# Altium schematic sheet BP6A_EVK_3.SchDoc(BP6A_EVK_3)
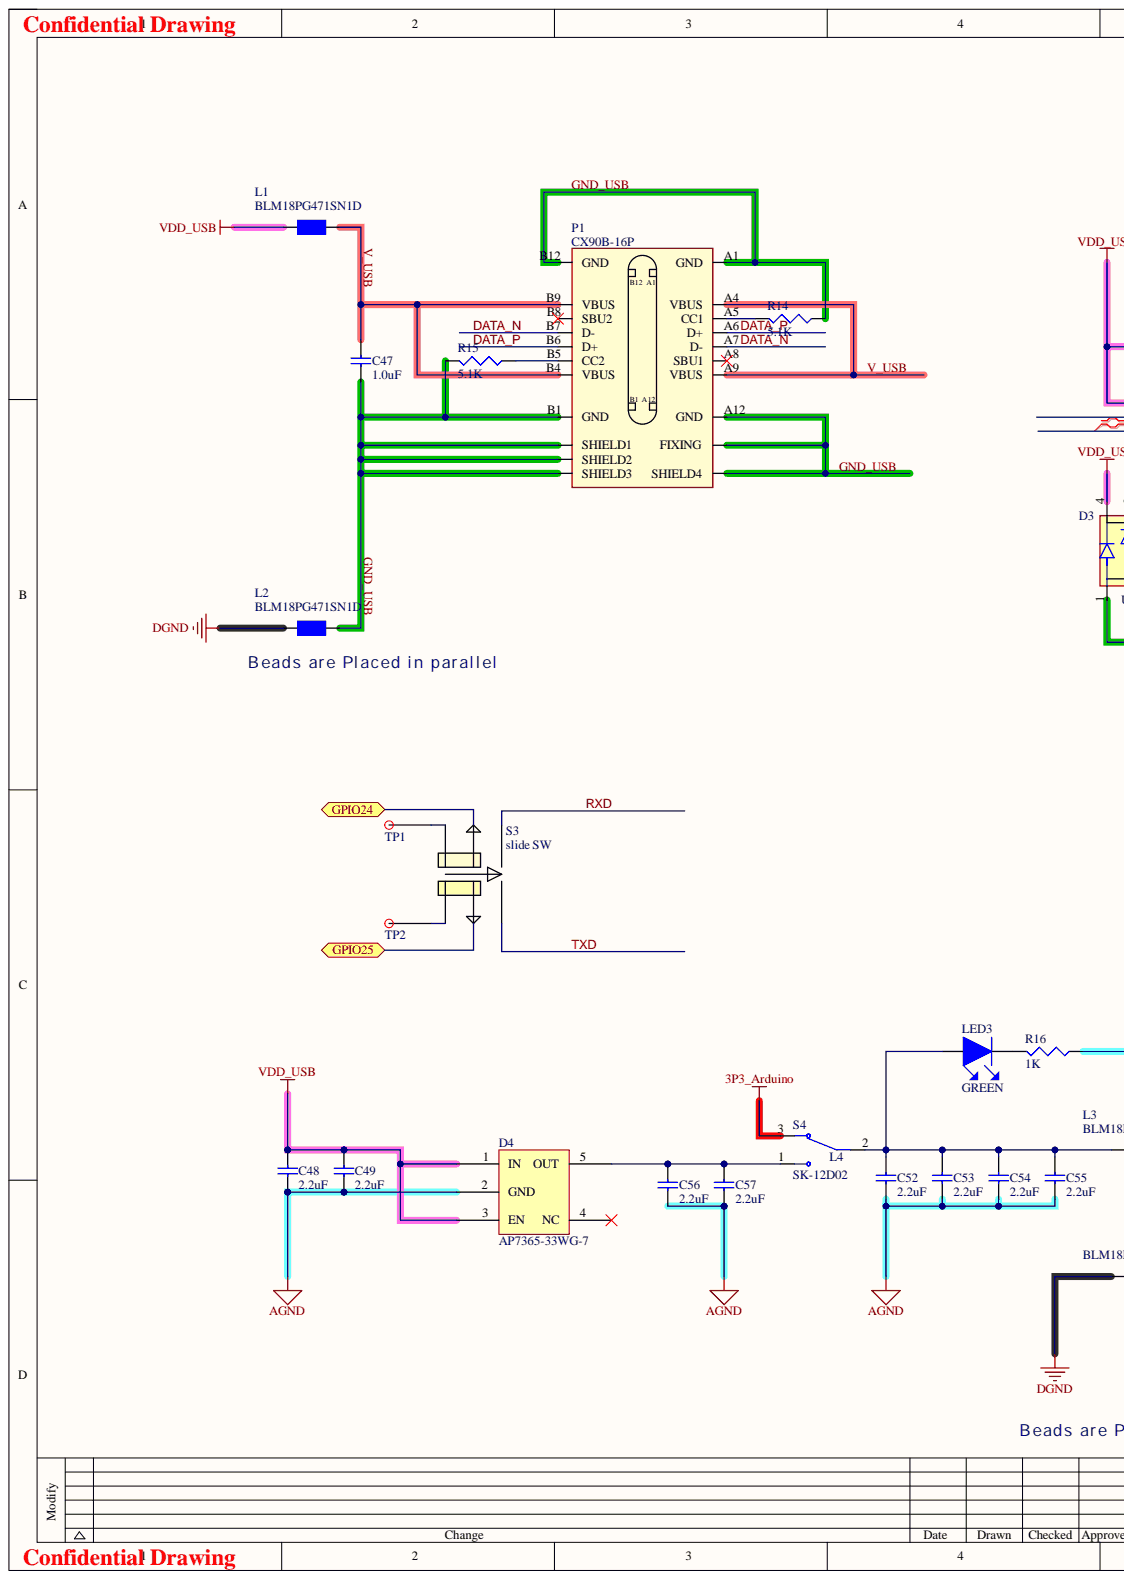
[diagram p0: BP6A_EVK_3.SchDoc, left]
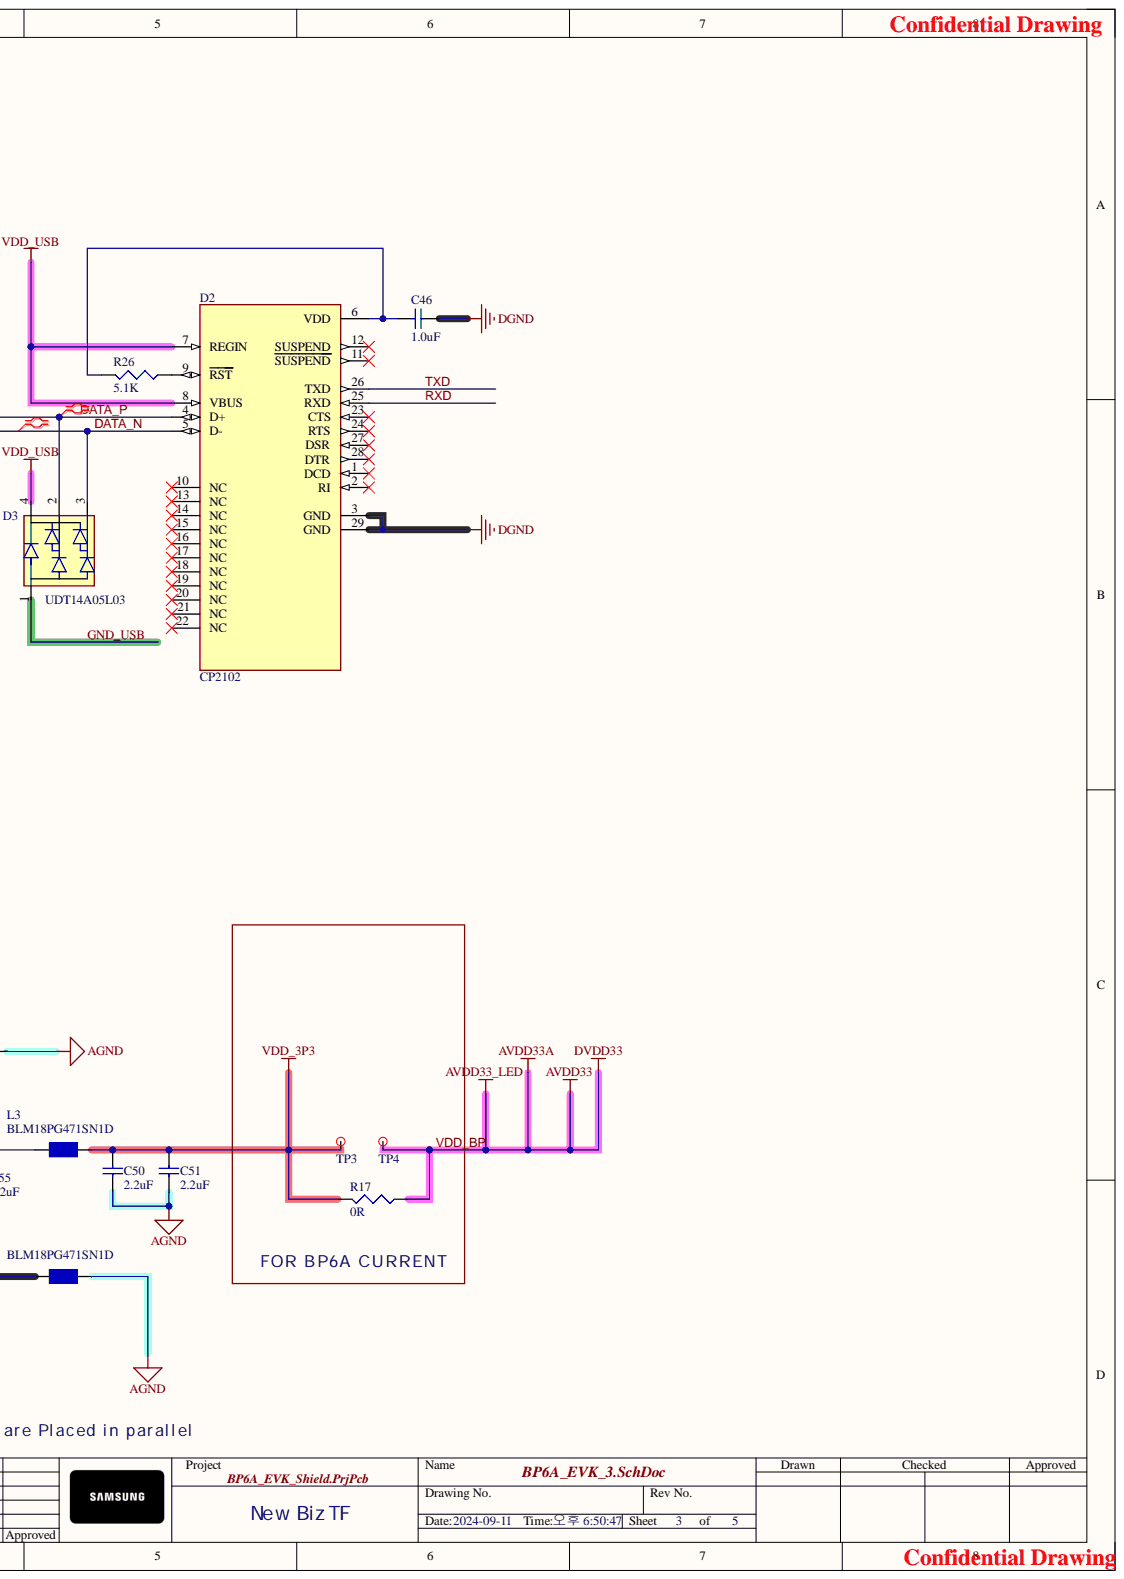
[diagram p1: BP6A_EVK_3.SchDoc, right]

## Parts
C46  1.0uF  [MLCC_1005]
C47  1.0uF  [MLCC_1005]
C48  2.2uF  [MLCC_1005]
C49  2.2uF  [MLCC_1005]
C50  2.2uF  [MLCC_1005]
C51  2.2uF  [MLCC_1005]
C52  2.2uF  [MLCC_1005]
C53  2.2uF  [MLCC_1005]
C54  2.2uF  [MLCC_1005]
C55  2.2uF  [MLCC_1005]
C56  2.2uF  [MLCC_1005]
C57  2.2uF  [MLCC_1005]
D2  CP2102  [CP2102]
D3  UDT14A05L03  [SOT143]
D4  AP7365-33WG-7  [AP7365-33WG-7]
L1  BLM18PG471SN1D  [BEAD_0603]
L2  BLM18PG471SN1D  [BEAD_0603]
L3  BLM18PG471SN1D  [BEAD_0603]
L4  BLM18PG471SN1D  [BEAD_0603]
LED3  GREEN  [LED_1608]
P1  CX90B-16P  [CX90B-16P]
R14  5.1K  [RES_1005]
R15  5.1K  [RES_1005]
R16  1K  [RES_1005]
R17  0R  [RES_1005]
R26  5.1K  [RES_1005]
S3  slide SW  [CTS-2235]
S4  SK-12D02  [SW_EG1247]
TP1  TP_smallPAD  [TP_small_PAD]  (value not drawn)
TP2  TP_smallPAD  [TP_small_PAD]  (value not drawn)
TP3  VIA  [TP_VIA]  (value not drawn)
TP4  VIA  [TP_VIA]  (value not drawn)

## Ports
GPIO24, GPIO25

## Nets
3P3_Arduino: S4.3
AGND: C48.1 C49.1 C50.1 C51.1 C52.1 C53.1 C54.1 C55.1 C56.1 C57.1 D4.2(GND) L4.2 R16.1
DGND: C46.1 D2.3(GND) D2.29(GND) L2.1 L4.1
VDD_3P3: C50.2 C51.2 L3.2 R17.2 TP3.1
VDD_USB: C48.2 C49.2 D2.7(REGIN) D2.8(VBUS) D3.4 D4.1(IN) D4.3(EN) L1.1
DATA_N: D2.5(D-) D3.3 P1.A7(D-) P1.B7(D-)
DATA_P: D2.4(D+) D3.2 P1.A6(D+) P1.B6(D+)
GND_USB: C47.1 D3.1 L2.2 P1.A1(GND) P1.A12(GND) P1.B1(GND) P1.B12(GND) P1.FIXING P1.SHIELD1 P1.SHIELD2 P1.SHIELD3 P1.SHIELD4 R14.1 R15.2
GPIO24: S3.5 ; port GPIO24
GPIO25: S3.2 ; port GPIO25
RXD: D2.25(RXD) S3.4
TXD: D2.26(TXD) S3.3
V_USB: C47.2 L1.2 P1.A4(VBUS) P1.A9(VBUS) P1.B4(VBUS) P1.B9(VBUS)
VDD_BP: R17.1 TP4.1
NetC46_2: C46.2 D2.6(VDD) R26.2
NetC52_2: C52.2 C53.2 C54.2 C55.2 L3.1 LED3.1 S4.2
NetC56_2: C56.2 C57.2 D4.5(OUT) S4.1
NetD2_9: D2.9(RST) R26.1
NetLED3_2: LED3.2 R16.2
NetP1_A5: P1.A5(CC1) R14.2
NetP1_B5: P1.B5(CC2) R15.1
NetS3_1: S3.1 TP2.1
NetS3_6: S3.6 TP1.1

Not connected: D2 1(DCD) 2(RI) 10(NC) 11(SUSPEND) 12(SUSPEND) 13(NC) 14(NC) 15(NC) 16(NC) 17(NC) 18(NC) 19(NC) 20(NC) 21(NC) 22(NC) 23(CTS) 24(RTS) 27(DSR) 28(DTR); D4 4(NC); P1 A8(SBU1) B8(SBU2)

## Library data of the parts
C46 C47 = MLCC_1: 0603MLCC, ±10%; Part Number: C0603-4µ7; Artikkelnr Noca: 20069060; Artikkelnr Simpro: N.A.; Note: 6.3V; Value: 4.7µF; Component Kind: Standard; Footprint Doc: 0603; LatestRevisionDate: 2009-11-23; Pin Count: 2; Published: 2009-05-08; Library Name: pebble.SCHLIB; Publisher: Nordic Semiconductor
C48-C57 = MLCC: Component Kind: Standard; Description: MLCC; Library Name: my_components.IntLib
D2 = CP2102: USB-UART IC; Component Kind: Standard; Library Name: my_components.IntLib
D3 = UDT14A05L03: MF: {'manufacturer': u'Littelfuse Inc.'}; MP: SP0503BAHTG; Availability: Unavailable; Component Kind: Standard; Description: UDT14A05L03; Library Name: my_component.SchLib; Package: None; Price: None
D4 = AP7365-33WG-7: 3.3V LDO; Vout: 3.3V / 600mA; Component Kind: Standard; Library Name: my_components.IntLib
L1-L4 = BEAD_1608: FERRITE SMD 0603 470R 1A 0.2R; Manufacturer: Murata; Code Arduino: 2200; DC Resistance (DCR): 200mR; Test Frequency: 100MHz; Tolerance: 25%; Case Code (Imperial): 0603; Case Code (Metric): 1608; Component Kind: Standard; Current: 1A; Device Type: Ferrite; Library Name: my_components.IntLib; Max DC Current: 1A; Max Operating Temperature: 125°C; Min Operating Temperature: -55°C; Package Quantity: 1; Packaging: Tape and Reel; Part Number: BLM18PG471SN1D
LED3 = LED_1005: 1005 LED; Component Kind: Standard; Library Name: my_components.IntLib
P1 = USB_C_CON: CONN RCPT USB3.1 TYPEC 16POS SMD  CX90B-16P; Manufacturer: Hirose; Code Arduino: J0272; Component Kind: Standard; Library Name: my_components.IntLib; Part Number: CX90B-16P
R14-R17 R26 = Res: 0603Chip Resistor; Value: 10K; Component Kind: Standard; LatestRevisionDate: 29-May-2009; LatestRevisionNote: IPC-7351 Footprint Added.; PackageDescription: Chip Resistor; PackageReference: J1-0603; Published: 8-Jun-2000; Signal Integrity: Res; Simulation: RESISTOR; Library Name: pebble.SCHLIB; Publisher: Altium Limited
S3 = MSL-1C2P(Dual): Component Kind: Standard; Description: MSL-1C2P(Dual); Library Name: my_component.SchLib
S4 = EG1247: Slide Switch SPDT Through Hole, Right Angle; Component Kind: Standard; Library Name: my_components.IntLib; LINK: https://www.devicemart.co.kr/goods/view?no=14566
TP3 TP4 = TP_VIA: Component Kind: Standard; Library Name: my_component.SchLib
TP1 TP2 = TP_smallPAD: Component Kind: Standard; Library Name: my_components.IntLib
